# Altium schematic sheet 06_LMS7002M_RF.SchDoc(06_LMS7002M_RF)
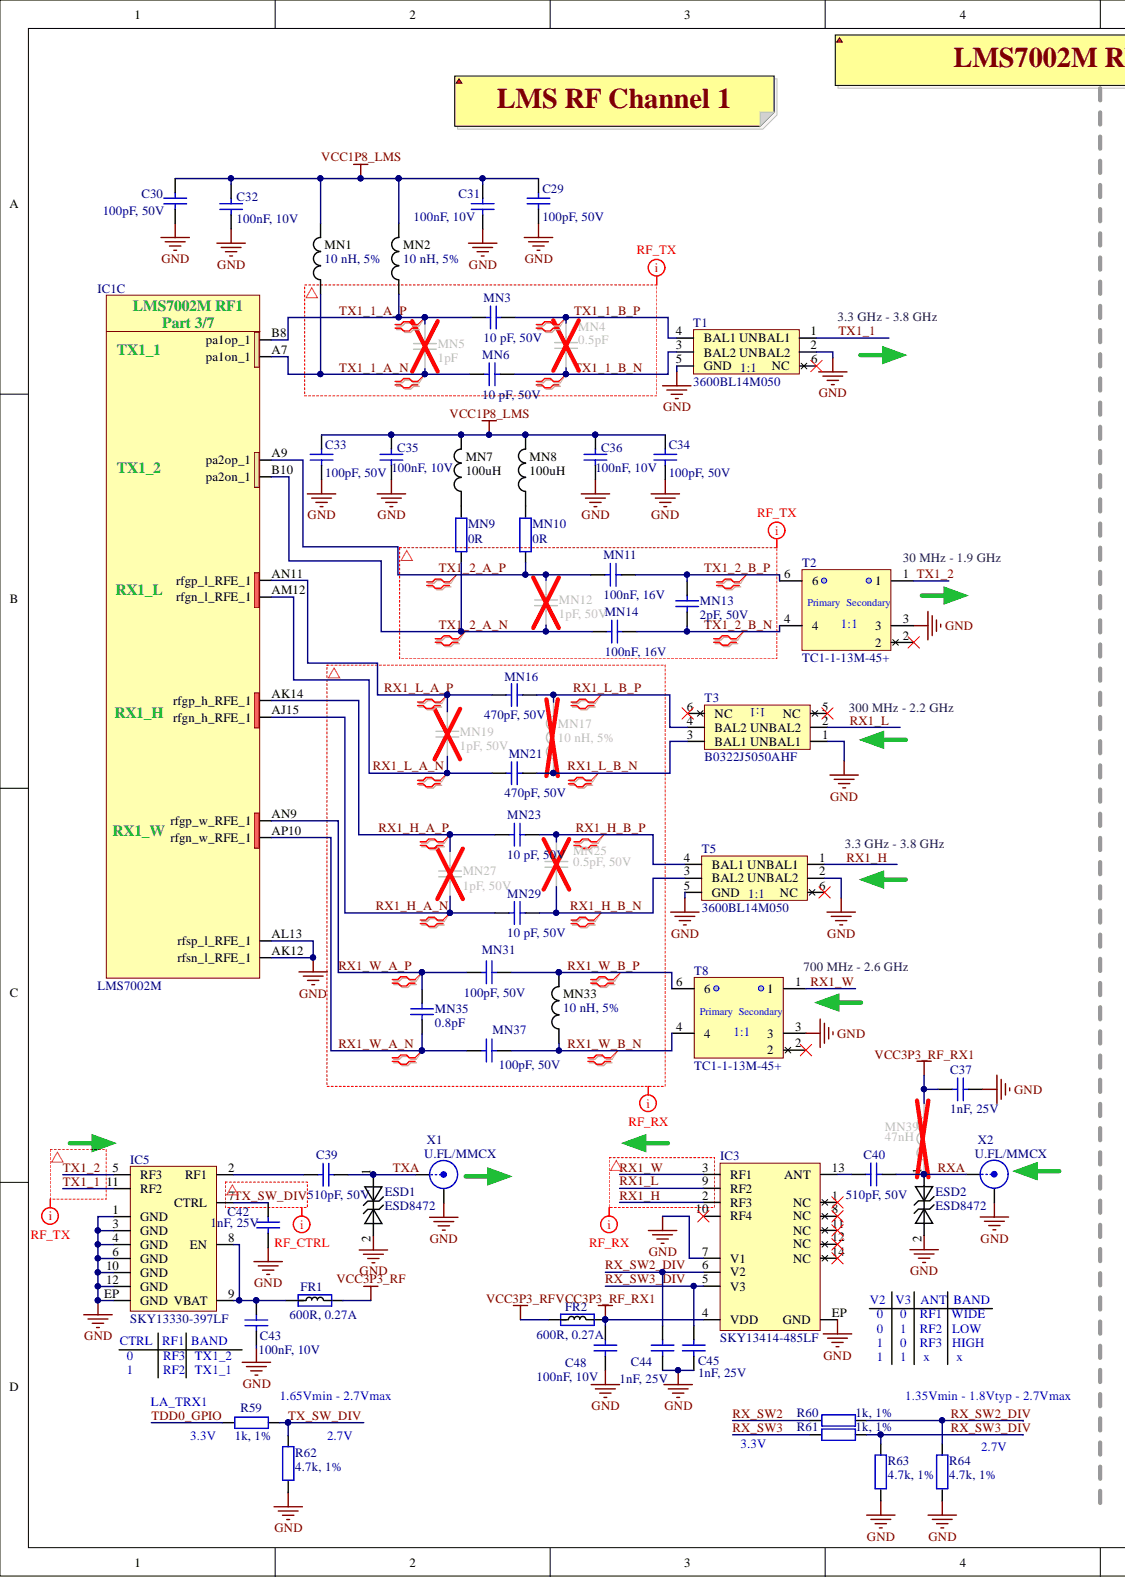
[diagram p0: 06_LMS7002M_RF.SchDoc, left]
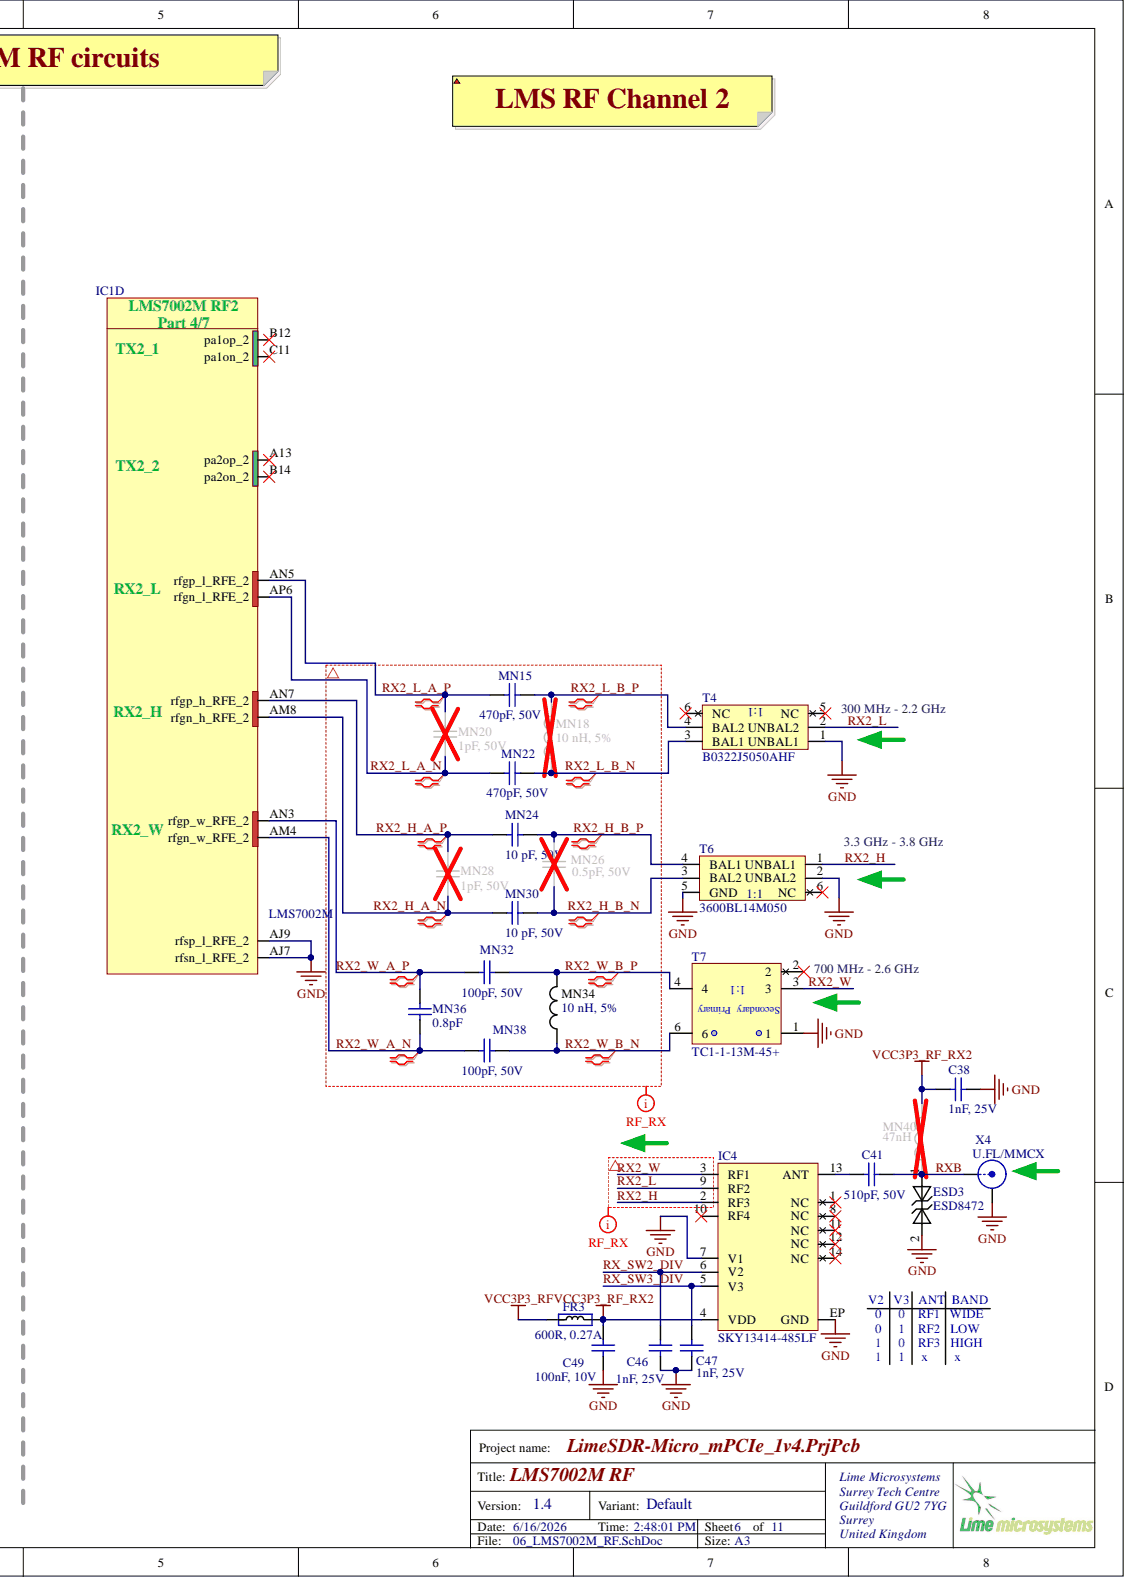
[diagram p1: 06_LMS7002M_RF.SchDoc, right]

## Parts
C29  100pF, 50V  Voltage Rating (DC): 50V  [CAP0201]
C30  100pF, 50V  Voltage Rating (DC): 50V  [CAP0201]
C31  100nF, 10V  Voltage Rating (DC): 10V  [CAP0201]
C32  100nF, 10V  [CAP0201]
C33  100pF, 50V  [CAP0201]
C34  100pF, 50V  Voltage Rating (DC): 50V  [CAP0201]
C35  100nF, 10V  [CAP0201]
C36  100nF, 10V  [CAP0201]
C37  1nF, 25V  Voltage - Rated: 25V  [CAP0201]
C38  1nF, 25V  Voltage - Rated: 25V  [CAP0201]
C39  510pF, 50V  Voltage - Rated: 50V  [CAP0402]
C40  510pF, 50V  Voltage - Rated: 50V  [CAP0402]
C41  510pF, 50V  Voltage - Rated: 50V  [CAP0402]
C42  1nF, 25V  Voltage - Rated: 25V  [CAP0201]
C43  100nF, 10V  Voltage Rating (DC): 10V  [CAP0201]
C44  1nF, 25V  Voltage - Rated: 25V  [CAP0201]
C45  1nF, 25V  Voltage - Rated: 25V  [CAP0201]
C46  1nF, 25V  Voltage - Rated: 25V  [CAP0201]
C47  1nF, 25V  Voltage - Rated: 25V  [CAP0201]
C48  100nF, 10V  Voltage Rating (DC): 10V  [CAP0201]
C49  100nF, 10V  Voltage Rating (DC): 10V  [CAP0201]
ESD1  ESD8472  [ESD8472_X3DFN2]
ESD2  ESD8472  [ESD8472_X3DFN2]
ESD3  ESD8472  [ESD8472_X3DFN2]
FR1  600R, 0.27A  [RES0201]
FR2  600R, 0.27A  [RES0201]
FR3  600R, 0.27A  [RES0201]
IC1  LMS7002M  [LMS7002M_v5_LESS_SILK]  (parts C,D)
IC3  SKY13414-485LF  [SKY13414-485LF_RF_SW_QFN14]
IC4  SKY13414-485LF  [SKY13414-485LF_RF_SW_QFN14]
IC5  SKY13330-397LF  [SKY13330-397LF_RF_SW_QFN12]
MN1  10 nH, 5%  [RES0402]
MN2  10 nH, 5%  Tolerance: 5%  [RES0402]
MN3  10 pF, 50V  Voltage Rating (DC): 50V  [CAP0402]
MN4  0.5pF  [CAP0402]
MN5  1pF  [CAP0402]
MN6  10 pF, 50V  Voltage Rating (DC): 50V  [CAP0402]
MN7  100uH  [RES0805]
MN8  100uH  [RES0805]
MN9  0R  [RES0402]
MN10  0R  [RES0402]
MN11  100nF, 16V  [CAP0402]
MN12  1pF, 50V  Voltage - Rated: 50V  [CAP0402]
MN13  2pF, 50V  [CAP0402]
MN14  100nF, 16V  [CAP0402]
MN15  470pF, 50V  Voltage - Rated: 50V  [CAP0402]
MN16  470pF, 50V  Voltage - Rated: 50V  [CAP0402]
MN17  10 nH, 5%  [RES0402]
MN18  10 nH, 5%  [RES0402]
MN19  1pF, 50V  Voltage - Rated: 50V  [CAP0402]
MN20  1pF, 50V  Voltage - Rated: 50V  [CAP0402]
MN21  470pF, 50V  Voltage - Rated: 50V  [CAP0402]
MN22  470pF, 50V  Voltage - Rated: 50V  [CAP0402]
MN23  10 pF, 50V  [CAP0402]
MN24  10 pF, 50V  Voltage Rating (DC): 50V  [CAP0402]
MN25  0.5pF, 50V  Voltage - Rated: 50V  [CAP0402]
MN26  0.5pF, 50V  Voltage - Rated: 50V  [CAP0402]
MN27  1pF, 50V  [CAP0402]
MN28  1pF, 50V  [CAP0402]
MN29  10 pF, 50V  Voltage Rating (DC): 50V  [CAP0402]
MN30  10 pF, 50V  Voltage Rating (DC): 50V  [CAP0402]
MN31  100pF, 50V  Voltage - Rated: 50V  [CAP0402]
MN32  100pF, 50V  Voltage - Rated: 50V  [CAP0402]
MN33  10 nH, 5%  [RES0402]
MN34  10 nH, 5%  [RES0402]
MN35  0.8pF  [CAP0402]
MN36  0.8pF  [CAP0402]
MN37  100pF, 50V  [CAP0402]
MN38  100pF, 50V  Voltage - Rated: 50V  [CAP0402]
MN39  47nH  [RES0402]
MN40  47nH  [RES0402]
R59  1k, 1%  Tolerance: 1%  [RES0201]
R60  1k, 1%  Tolerance: 1%  [RES0201]
R61  1k, 1%  Tolerance: 1%  [RES0201]
R62  4.7k, 1%  Tolerance: 1%  [RES0201]
R63  4.7k, 1%  Tolerance: 1%  [RES0201]
R64  4.7k, 1%  Tolerance: 1%  [RES0201]
T1  3600BL14M050  Turns Ratio: 1:1  [3600BL14M050_balun_0603]
T2  TC1-1-13M-45+  [TC1-1-13MA+_JA4220-AL_]
T3  B0322J5050AHF  Turns Ratio: 1:1  [Anaren_0805_balun_B0322J5050AHF]
T4  B0322J5050AHF  Turns Ratio: 1:1  [Anaren_0805_balun_B0322J5050AHF]
T5  3600BL14M050  Turns Ratio: 1:1  [3600BL14M050_balun_0603]
T6  3600BL14M050  Turns Ratio: 1:1  [3600BL14M050_balun_0603]
T7  TC1-1-13M-45+  [TC1-1-13MA+_JA4220-AL_]
T8  TC1-1-13M-45+  [TC1-1-13MA+_JA4220-AL_]
X1  U.FL/MMCX  [MMCX_UFL_conn_u_v2]
X2  U.FL/MMCX  [MMCX_UFL_conn_u_v2]
X4  U.FL/MMCX  [MMCX_UFL_conn_u_v2]

## Nets
GND: C29.1 C30.1 C31.2 C32.2 C33.1 C34.1 C35.2 C36.2 C37.2 C38.2 C42.2 C43.2 C44.2 C45.2 C46.2 C47.2 C48.2 C49.2 ESD1.2 ESD2.2 ESD3.2 IC1.AJ7(rfsn_l_RFE_2) IC1.AJ9(rfsp_l_RFE_2) IC1.AK12(rfsn_l_RFE_1) IC1.AL13(rfsp_l_RFE_1) IC3.7(V1) IC3.EP(GND) IC4.7(V1) IC4.EP(GND) IC5.1(GND) IC5.3(GND) IC5.4(GND) IC5.6(GND) IC5.10(GND) IC5.12(GND) IC5.EP(GND) R62.2 R63.2 R64.2 T1.2(UNBAL2) T1.5(GND) T2.3 T3.1(UNBAL1) T4.1(UNBAL1) T5.2(UNBAL2) T5.5(GND) T6.2(UNBAL2) T6.5(GND) T7.1 T8.3 X1.2 X2.2 X4.2
VCC1P8_LMS: C29.2 C30.2 C31.1 C32.1 C33.2 C34.2 C35.1 C36.1 MN1.2 MN2.2 MN7.2 MN8.2
VCC3P3_RF: FR1.1 FR2.2 FR3.2
VCC3P3_RF_RX1: C37.1 C48.1 FR2.1 IC3.4(VDD) MN39.2
VCC3P3_RF_RX2: C38.1 C49.1 FR3.1 IC4.4(VDD) MN40.2
RX1_H: IC3.2(RF3) T5.1(UNBAL1)
RX1_H_A_N: IC1.AJ15(rfgn_h_RFE_1) MN27.1 MN29.2
RX1_H_A_P: IC1.AK14(rfgp_h_RFE_1) MN23.2 MN27.2
RX1_H_B_N: MN25.1 MN29.1 T5.3(BAL2)
RX1_H_B_P: MN23.1 MN25.2 T5.4(BAL1)
RX1_L: IC3.9(RF2) T3.2(UNBAL2)
RX1_L_A_N: IC1.AM12(rfgn_l_RFE_1) MN19.1 MN21.2
RX1_L_A_P: IC1.AN11(rfgp_l_RFE_1) MN16.2 MN19.2
RX1_L_B_N: MN17.1 MN21.1 T3.3(BAL1)
RX1_L_B_P: MN16.1 MN17.2 T3.4(BAL2)
RX1_W: IC3.3(RF1) T8.1
RX1_W_A_N: IC1.AP10(rfgn_w_RFE_1) MN35.1 MN37.2
RX1_W_A_P: IC1.AN9(rfgp_w_RFE_1) MN31.2 MN35.2
RX1_W_B_N: MN33.1 MN37.1 T8.4
RX1_W_B_P: MN31.1 MN33.2 T8.6
RX2_H: IC4.2(RF3) T6.1(UNBAL1)
RX2_H_A_N: IC1.AM8(rfgn_h_RFE_2) MN28.1 MN30.2
RX2_H_A_P: IC1.AN7(rfgp_h_RFE_2) MN24.2 MN28.2
RX2_H_B_N: MN26.1 MN30.1 T6.3(BAL2)
RX2_H_B_P: MN24.1 MN26.2 T6.4(BAL1)
RX2_L: IC4.9(RF2) T4.2(UNBAL2)
RX2_L_A_N: IC1.AP6(rfgn_l_RFE_2) MN20.1 MN22.2
RX2_L_A_P: IC1.AN5(rfgp_l_RFE_2) MN15.2 MN20.2
RX2_L_B_N: MN18.1 MN22.1 T4.3(BAL1)
RX2_L_B_P: MN15.1 MN18.2 T4.4(BAL2)
RX2_W: IC4.3(RF1) T7.3
RX2_W_A_N: IC1.AM4(rfgn_w_RFE_2) MN36.1 MN38.2
RX2_W_A_P: IC1.AN3(rfgp_w_RFE_2) MN32.2 MN36.2
RX2_W_B_N: MN34.1 MN38.1 T7.6
RX2_W_B_P: MN32.1 MN34.2 T7.4
RX_SW2: R60.2
RX_SW2_DIV: C44.1 C46.1 IC3.6(V2) IC4.6(V2) R60.1 R64.1
RX_SW3: R61.2
RX_SW3_DIV: C45.1 C47.1 IC3.5(V3) IC4.5(V3) R61.1 R63.1
RXA: C40.1 ESD2.1 MN39.1 X2.1
RXB: C41.1 ESD3.1 MN40.1 X4.1
TDD0_GPIO: R59.2
TX1_1: IC5.11(RF2) T1.1(UNBAL1)
TX1_1_A_N: IC1.A7(pa1on_1) MN1.1 MN5.1 MN6.2
TX1_1_A_P: IC1.B8(pa1op_1) MN2.1 MN3.1 MN5.2
TX1_1_B_N: MN4.2 MN6.1 T1.3(BAL2)
TX1_1_B_P: MN3.2 MN4.1 T1.4(BAL1)
TX1_2: IC5.5(RF3) T2.1
TX1_2_A_N: IC1.B10(pa2on_1) MN9.1 MN12.2 MN14.1
TX1_2_A_P: IC1.A9(pa2op_1) MN10.1 MN11.2 MN12.1
TX1_2_B_N: MN13.1 MN14.2 T2.4
TX1_2_B_P: MN11.1 MN13.2 T2.6
TX_SW_DIV: C42.1 IC5.7(CTRL) R59.1 R62.1
TXA: C39.1 ESD1.1 X1.1
NetC39_2: C39.2 IC5.2(RF1)
NetC40_2: C40.2 IC3.13(ANT)
NetC41_2: C41.2 IC4.13(ANT)
NetC43_1: C43.1 FR1.2 IC5.8(EN) IC5.9(VBAT)
NetMN7_1: MN7.1 MN9.2
NetMN8_1: MN8.1 MN10.2
N00140:
N00141:
N00142:
N00143:
N00144:
N00145:
N00146:
N00147:

Not connected: IC1 A13(pa2op_2) B12(pa1op_2) B14(pa2on_2) C11(pa1on_2); IC3 1(NC) 8(NC) 10(RF4) 11(NC) 12(NC) 14(NC); IC4 1(NC) 8(NC) 10(RF4) 11(NC) 12(NC) 14(NC); T1 6(NC); T2 2; T3 5(NC) 6(NC); T4 5(NC) 6(NC); T5 6(NC); T6 6(NC); T7 2; T8 2

## Library data of the parts
C29 C30 C33 C34 = Cap_0402: Part Description: 100 pF ±5% 50V Ceramic Capacitor C0G, NP0 0201 (0603 Metric); Manufacturer Part Number 1: C0603C0G1H101J030BA; Manufacturer: TDK; Tolerance: 5%; Insulation Resistance: 10GR; Dielectric Material: Ceramic; Dielectric: C0G; Width: 0.012inch; Weight: 6E-06oz; Voltage Rating: 50V; Voltage: 50V; Value: 100pF, 50V; Thickness: 0.013inch; Termination: SMD/SMT; Supplier Part Number 1: 445-1786-1-ND; Supplier 1: Digi-Key; Stock 1: 367528; Series: C; RoHS Compliant: Yes; RoHS: Yes; Reach SVHC Compliant: Yes; Pricing 1: 1=0,10000, 10=0,06000, 50=0,03280, 100=0,02670, 500=0,01908, 1000=0,01500, 2500=0,01364, 5000=0,01254 (USD); Packaging: Tape and Reel; Package Quantity: 1; Number of Pins: 2; Mount: Surface Mount; Min Operating Temperature: -55°C; Max Operating Temperature: 125°C; Length: 0.6mm; Lead Free: Lead Free; Height: 0.3mm; Depth: 0.3mm; Construction: Flat; ComponentLink3URL: https://datasheet.ciiva.com/6250/tdkmlcccaprange-6250351.pdf?src-supplier=Digi-Key; ComponentLink3Description: https://datasheet.ciiva.com/6250/tdkmlcccaprange-6250351.pdf?src-supplier=Digi-Key; ComponentLink2URL: https://datasheet.ciiva.com/16205/mlcc-commercial-general-en-16205590.pdf?src-supplier=Future+Electronics; ComponentLink2Description: https://datasheet.ciiva.com/16205/mlcc-commercial-general-en-16205590.pdf?src-supplier=Future+Electronics; ComponentLink1URL: https://datasheet.ciiva.com/24217/c0603c0g1h101j030ba-264106-24217425.pdf?src-supplier=Mouser; ComponentLink1Description: https://datasheet.ciiva.com/24217/c0603c0g1h101j030ba-264106-24217425.pdf?src-supplier=Mouser; Component Kind: Standard; Case Code (Metric): 0603; Case Code (Imperial): 0201; Case/Package: 0201; Capacitance: 100pF; Library Name: LimeMicroAltiumLib_schLib.SchLib
  C33: Voltage Rating (DC): 50V
C31 C32 C35 C36 C43 C48 C49 = Cap_0402: Part Description: 0.1 µF ±20% 10V Ceramic Capacitor X5R 0201 (0603 Metric); Manufacturer Part Number 1: CL03A104MP3NNNC; Manufacturer: Samsung; Tolerance: 20%; Width: 0.012inch; Voltage Rating: 10V; Value: 100nF, 10V; Thickness: 0.013inch; Supplier Part Number 1: 1276-1321-1-ND; Supplier 1: Digi-Key; Stock 1: 3817176; Series: CL; RoHS Compliant: Yes; RoHS: Yes; Pricing 1: 1=0,10000, 10=0,03000, 50=0,01640, 100=0,01330, 500=0,00950, 1000=0,00747, 2500=0,00679, 5000=0,00625 (USD); Packaging: Tape and Reel; Package Quantity: 1; Mount: Surface Mount; Min Operating Temperature: -55°C; Max Operating Temperature: 85°C; Length: 0.024inch; ComponentLink5URL: https://datasheet.ciiva.com/25788/cl03a104mp3nnnc-25788272.pdf?src-supplier=Digi-Key; ComponentLink5Description: https://datasheet.ciiva.com/25788/cl03a104mp3nnnc-25788272.pdf?src-supplier=Digi-Key; Component Kind: Standard; Case Code (Metric): 0603; Case Code (Imperial): 0201; Case/Package: 0201; Capacitance: 100nF; Library Name: LimeMicroAltiumLib_schLib.SchLib
  C32 C35 C36: Voltage Rating (DC): 10V
C37 C38 C42 C44-C47 = Cap_0402: Part Description: 1000pF ±10% 25V X7R Ceramic Capacitor -55°C ~ 125°C Surface Mount, MLCC 0201 (0603 Metric) 0.024" L x 0.012" W (0.60mm x 0.30mm); Manufacturer Part Number 1: C0603X7R1E102K030BA; Manufacturer: TDK Corporation; Tolerance: ±10%; Temperature Coefficient: X7R; Capacitance: 1000pF; Value: 1nF, 25V; Thickness (Max): 0.013" (0.33mm); Supplier Part Number 1: 445-7275-1-ND; Supplier 1: Digi-Key; Size / Dimension: 0.024" L x 0.012" W (0.60mm x 0.30mm); RoHS: RoHS Compliant; Ratings: -; Packaging: Cut Tape (CT); Package / Case: 0201 (0603 Metric); Operating Temperature: -55°C ~ 125°C; Mounting Type: Surface Mount, MLCC; Lead Style: -; Lead Spacing: -; Height - Seated (Max): -; Features: -; Component Kind: Standard; Category: Capacitors; Applications: General Purpose; Library Name: LimeMicroAltiumLib_schLib.SchLib
C39-C41 = Cap_0402: Part Description: 510pF ±5% 50V C0G, NP0 Ceramic Capacitor -55°C ~ 125°C Surface Mount, MLCC 0402 (1005 Metric) 0.039" L x 0.020" W (1.00mm x 0.50mm); Manufacturer Part Number 1: GRM1555C1H511JA01D; Manufacturer: Murata Electronics North America; Tolerance: ±5%; Temperature Coefficient: C0G, NP0; Value: 510pF, 50V; Thickness (Max): 0.022" (0.55mm); Supplier Part Number 1: 490-3237-1-ND; Supplier 1: Digi-Key; Size / Dimension: 0.039" L x 0.020" W (1.00mm x 0.50mm); RoHS: RoHS Compliant; Ratings: -; Packaging: Cut Tape (CT); Package / Case: 0402 (1005 Metric); Operating Temperature: -55°C ~ 125°C; Mounting Type: Surface Mount, MLCC; Lead Style: -; Lead Spacing: -; Height - Seated (Max): -; Features: -; Component Kind: Standard; Category: Capacitors; Capacitance: 510pF; Applications: General Purpose; Library Name: LimeMicroAltiumLib_schLib.SchLib
ESD1-ESD3 = ESD8472: Part Description: TVS DIODE 5.3VWM 14VC X3DFN2; Manufacturer Part Number 1: ESD8472MUT5G; Manufacturer: ON Semiconductor; Voltage - Reverse Standoff (Typ): 5.3V (Max); Voltage - Clamping (Max) @ Ipp: 14V; Voltage - Breakdown (Min): 7V; Power Line Protection: No; Current - Peak Pulse (10/1000µs): 3A (8/20µs); Capacitance @ Frequency: 0.2pF @ 1MHz; Type: Zener; Supplier Part Number 1: ESD8472MUT5GOSCT-ND; Supplier Device Package: 2-X3DFN (0.62x0.32); Supplier 1: Digi-Key; Stock 1: 2295; RoHS: RoHS Compliant; Pricing 1: 1=0.39, 10=0.315, 100=0.2142, 500=0.16068, 1000=0.12051 (USD); Power - Peak Pulse: -; Part Status: Active; Packaging: Cut Tape (CT); Package / Case: 2-XFDFN; Operating Temperature: -55°C ~ 150°C (TJ); Mounting Type: Surface Mount; ComponentLink1URL: http://www.onsemi.com/pub/Collateral/ESD8472-D.PDF; ComponentLink1Description: http://www.onsemi.com/pub/Collateral/ESD8472-D.PDF; Component Kind: Standard; Category: Circuit Protection; Applications: Automotive, RF Antenna; Library Name: LimeMicroAltiumLib_schLib.SchLib
FR1-FR3 = Ferrite: Part Description: FERRITE BEAD 600 OHM 0201 1LN; Manufacturer Part Number 1: BK0603TS601-T; Manufacturer: Taiyo Yuden; Value: 600R@100MHz; Impedance @ Frequency: 600 Ohm @ 100MHz; Filter Type: Differential Mode - Single; DC Resistance (DCR): 750 mOhm Max; Current Rating: 270mA; Supplier Part Number 1: 587-3277-1-ND; Supplier 1: Digi-Key; Size / Dimension: 0.024" L x 0.012" W (0.60mm x 0.30mm); RoHS: RoHS Compliant; Packaging: Cut Tape (CT); Package / Case: 0201 (0603 Metric); Mounting Type: Surface Mount; Height (Max): 0.013" (0.33mm); Component Kind: Standard; Category: Filters; Library Name: LimeMicroAltiumLib_schLib.SchLib
IC1 = LMS7002Mr4: Schematic symbol revision 4. Default footprint - 0.31mm pad; Part Description: IC FPRF Transceiver LMS7002M; 261 pin aQFN; Manufacturer: Lime Microsystems; Supplier Part Number 1: LMS7002M; Supplier 1: Lime Microsystems; Manufacturer Part Number 1: LMS7002M; Library Name: LimeMicroAltiumLib_schLib_r04.SchLib; Component Kind: Standard
IC3 IC4 = SKY13414-485LF_RF_SW: Part Description: RF Switch IC General Purpose SP4T 3 GHz 50Ohm 14-QFN (2x2); Manufacturer: Skyworks Solutions; Throw Configuration: SP4T; P1dB: 37dBm; Impedance: 50R; IIP3: 70dBm; Supplier Part Number 1: 863-1582-1-ND; Supplier 1: Digi-Key; Stock 1: 43522; RoHS Compliant: Yes; RoHS: Yes; Pricing 1: 1=1,35000, 10=1,20700, 25=1,14520, 100=0,94070, 250=0,87936, 500=0,77710, 1000=0,61350 (USD); Packaging: Tape and Reel; Package Quantity: 3000; Min Operating Temperature: -40°C; Max Operating Temperature: 85°C; Manufacturer Part Number 1: SKY13414-485LF; ComponentLink1URL: https://datasheet.ciiva.com/15309/sky13414-485lf-201689g-231640-15309794.pdf?src-supplier=Mouser; ComponentLink1Description: https://datasheet.ciiva.com/15309/sky13414-485lf-201689g-231640-15309794.pdf?src-supplier=Mouser; Component Kind: Standard; Case/Package: QFN; Library Name: LimeMicroAltiumLib_schLib_r04.SchLib
IC5 = SKY13330-397LF_RF_SW: Part Description: RF Switch IC W-CDMA SPDT 6 GHz 50Ohm 12-QFN (2x2); Manufacturer: Skyworks Solutions; Turn-On Delay Time: 1.6us; Throw Configuration: SPDT; P1dB: 39dBm; Max Frequency: 6GHz; Insertion Loss: 0.65dB; Impedance: 50R; Frequency: 100MHz; Weight: 0.000776oz; Turn-Off Delay Time: 1.6us; Supplier Part Number 1: 863-1643-1-ND; Supplier 1: Digi-Key; Stock 1: 596826; RoHS: Yes; Radiation Hardening: No; Pricing 1: 1=0,99000, 10=0,88800, 25=0,84280, 100=0,69230, 250=0,64716, 500=0,57190, 1000=0,45150 (USD); Packaging: Tape and Reel; Package Quantity: 3000; Number of Pins: 12; Mount: Surface Mount; Min Operating Temperature: -40°C; Max Operating Temperature: 85°C; Manufacturer Part Number 1: SKY13330-397LF; Contact Plating: Tin,Matte; ComponentLink1URL: https://datasheet.ciiva.com/15100/getdatasheetpartid-656010-15100460.pdf?src-supplier=Verical; ComponentLink1Description: https://datasheet.ciiva.com/15100/getdatasheetpartid-656010-15100460.pdf?src-supplier=Verical; Component Kind: Standard; Case/Package: QFN; Library Name: LimeMicroAltiumLib_schLib_r04.SchLib
MN1 MN2 MN17 MN18 MN33 MN34 = Inductor_0603: Part Description: RF Inductors - SMD 10 NH 5%; Manufacturer Part Number 1: LQW15AN10NJ00D; Manufacturer: Murata; Test Frequency: 100MHz; Shielding: Unshielded; Self Resonant Frequency: 5.5GHz; Rated Current-Max: 500mA; Q Factor: 25; Inductance: 10nH; DC Resistance (DCR): 170mR; Core Material: Air,Ferrite; Width: 0.02inch; Weight: 2.8E-05oz; Termination: SMD/SMT; Supplier Part Number 1: 490-1146-6-ND; Supplier 1: Digi-Key; Stock 1: 158333; Series: LQW15A_00; RoHS Compliant: Yes; RoHS: Yes; Resistor Type: Wirewound; Radiation Hardening: No; Pricing 1: 1=0,16000, 10=0,14200, 25=0,12600, 50=0,10720, 100=0,09450, 250=0,08664, 500=0,07876, 1000=0,06615, 2500=0,05670 (USD); Packaging: Tape and Reel; Package Quantity: 10000; Mount: Surface Mount; Min Operating Temperature: -55°C; Military Standard: Not; Max Operating Temperature: 125°C; Max DC Current: 500mA; Length: 1mm; Lead Free: Lead Free; Height: 0.5mm; Depth: 0.7mm; DC Current: 500mA; Current Rating: 500mA; ComponentLink7URL: https://datasheet.ciiva.com/16210/l0075s0080lqw15a-00-16210412.pdf?src-supplier=Future+Electronics; ComponentLink7Description: https://datasheet.ciiva.com/16210/l0075s0080lqw15a-00-16210412.pdf?src-supplier=Future+Electronics; ComponentLink6URL: https://datasheet.ciiva.com/18089/getdatasheetpartid-110925-18089287.pdf?src-supplier=Verical; ComponentLink6Description: https://datasheet.ciiva.com/18089/getdatasheetpartid-110925-18089287.pdf?src-supplier=Verical; ComponentLink5URL: https://datasheet.ciiva.com/8508/l0075s0080lqw15a-00-8508743.pdf?src-supplier=Avnet; ComponentLink5Description: https://datasheet.ciiva.com/8508/l0075s0080lqw15a-00-8508743.pdf?src-supplier=Avnet; ComponentLink4URL: https://datasheet.ciiva.com/1042/l0075s0080lqw15a-00-1042164.pdf?src-supplier=Digi-Key; ComponentLink4Description: https://datasheet.ciiva.com/1042/l0075s0080lqw15a-00-1042164.pdf?src-supplier=Digi-Key; ComponentLink3URL: https://datasheet.ciiva.com/1042/l0075s0080lqw15a-00-1042163.pdf?src-supplier=Digi-Key; ComponentLink3Description: https://datasheet.ciiva.com/1042/l0075s0080lqw15a-00-1042163.pdf?src-supplier=Digi-Key; ComponentLink2URL: https://datasheet.ciiva.com/1042/l0075s0080lqw15a-00-1042162.pdf?src-supplier=Digi-Key; ComponentLink2Description: https://datasheet.ciiva.com/1042/l0075s0080lqw15a-00-1042162.pdf?src-supplier=Digi-Key; ComponentLink1URL: https://datasheet.ciiva.com/11799/0900766b8090d800-11799037.pdf?src-supplier=RSComponents; ComponentLink1Description: https://datasheet.ciiva.com/11799/0900766b8090d800-11799037.pdf?src-supplier=RSComponents; Component Kind: Standard; Case Code (Metric): 1005; Case Code (Imperial): 0402; Case/Package: 0402; Library Name: LimeMicroAltiumLib_schLib.SchLib
  MN1 MN17 MN18 MN33 MN34: Tolerance: 5%
MN3 MN6 MN23 MN24 MN29 MN30 = Cap_0402: Part Description: 10 pF ±0.5pF 50V Ceramic Capacitor C0G, NP0 0402 (1005 Metric); Manufacturer Part Number 1: 0402N100D500CT; Manufacturer: Walsin Technologies; Dielectric: C0G; Capacitance: 10pF; Voltage Rating: 50V; Supplier Part Number 1: 1292-0402N100D500CT-ND; Supplier 1: Digi-Key; Stock 1: 66767; RoHS Compliant: Yes; RoHS: Yes; Pricing 1: 1=0,10000, 10=0,01200, 50=0,00660, 100=0,00540, 500=0,00388, 1000=0,00306, 2500=0,00278, 5000=0,00256 (USD); Packaging: Tape and Reel; Min Operating Temperature: -55°C; Max Operating Temperature: 125°C; ComponentLink2URL: http://www.farnell.com/datasheets/1958513.pdf?src-supplier=Element14; ComponentLink2Description: http://www.farnell.com/datasheets/1958513.pdf?src-supplier=Element14; ComponentLink1URL: http://www.farnell.com/datasheets/2340522.pdf?src-supplier=Farnell; ComponentLink1Description: http://www.farnell.com/datasheets/2340522.pdf?src-supplier=Farnell; Component Kind: Standard; Case Code (Metric): 1005; Case Code (Imperial): 0402; Case/Package: 0402; Applications: General Purpose; Library Name: LimeMicroAltiumLib_schLib.SchLib
  MN23: Voltage Rating (DC): 50V
MN4 = Cap_0402: Part Description: 0.50pF ±0.25pF 50V C0G, NP0 Ceramic Capacitor -55°C ~ 125°C Surface Mount, MLCC 0402 (1005 Metric) 0.039" L x 0.020" W (1.00mm x 0.50mm); Manufacturer Part Number 1: CC0402CRNPO9BNR50; Manufacturer: Yageo; Voltage - Rated: 50V; Tolerance: ±0.25pF; Temperature Coefficient: C0G, NP0; Capacitance: 0.50pF; Value: 0.5pF; Thickness (Max): 0.022" (0.55mm); Supplier Part Number 1: 311-1001-1-ND; Supplier 1: Digi-Key; Size / Dimension: 0.039" L x 0.020" W (1.00mm x 0.50mm); RoHS: RoHS Compliant; Ratings: -; Packaging: Cut Tape (CT); Package / Case: 0402 (1005 Metric); Operating Temperature: -55°C ~ 125°C; Mounting Type: Surface Mount, MLCC; Lead Style: -; Lead Spacing: -; Height - Seated (Max): -; Features: -; Component Kind: Standard; Category: Capacitors; Applications: General Purpose; Library Name: LimeMicroAltiumLib_schLib.SchLib
MN5 = Cap_0402: Part Description: 1pF ±0.1pF 50V C0G, NP0 Ceramic Capacitor -55°C ~ 125°C Surface Mount, MLCC 0402 (1005 Metric) 0.039" L x 0.020" W (1.00mm x 0.50mm); Manufacturer Part Number 1: C1005C0G1H010B050BA; Manufacturer: TDK Corporation; Voltage - Rated: 50V; Tolerance: ±0.1pF; Temperature Coefficient: C0G, NP0; Value: 1pF; Thickness (Max): 0.022" (0.55mm); Supplier Part Number 1: 445-4854-6-ND; Supplier 1: Digi-Key; Size / Dimension: 0.039" L x 0.020" W (1.00mm x 0.50mm); RoHS: RoHS Compliant; Ratings: -; Packaging: Digi-Reel®; Package / Case: 0402 (1005 Metric); Operating Temperature: -55°C ~ 125°C; Mounting Type: Surface Mount, MLCC; Lead Style: -; Lead Spacing: -; Height - Seated (Max): -; Features: -; Component Kind: Standard; Category: Capacitors; Capacitance: 1pF; Applications: General Purpose; Library Name: LimeMicroAltiumLib_schLib.SchLib
MN7 MN8 = Inductor_0603: Part Description: FIXED IND 100UH 2MA 3.1 OHM SMD; Manufacturer Part Number 1: MLF2012C101KT000; Manufacturer: TDK Corporation; Tolerance: ±10%; Shielding: Shielded; Q @ Freq: 25 @ 1MHz; Material - Core: Ferrite; Inductance: 100µH; Frequency - Test: 1MHz; Frequency - Self Resonant: 7MHz; DC Resistance (DCR): 3.1 Ohm Max; Current Rating: 2mA; Value: 100uH; Type: Multilayer; Supplier Part Number 1: 445-1071-1-ND; Supplier Device Package: 0805 (2012 Metric); Supplier 1: Digi-Key; Size / Dimension: 0.079" L x 0.049" W (2.00mm x 1.25mm); RoHS: RoHS Compliant; Packaging: Cut Tape (CT); Package / Case: 0805 (2012 Metric); Operating Temperature: -40°C ~ 85°C; Mounting Type: Surface Mount; Height - Seated (Max): 0.057" (1.45mm); Current - Saturation: -; Component Kind: Standard; Category: Inductors, Coils, Chokes; Library Name: LimeMicroAltiumLib_schLib.SchLib
MN9 MN10 = Res_0402: Part Description: RES SMD 0.0OHM JUMPER 1/16W 0402; Manufacturer Part Number 1: RC0402JR-070RL; Manufacturer: Yageo; Tolerance: Jumper; Resistance (Ohms): 0.0; Power (Watts): 0.063W, 1/16W; Value: 0R; Temperature Coefficient: -; Supplier Part Number 1: 311-0.0JRTR-ND; Supplier Device Package: 0402; Supplier 1: Digi-Key; Size / Dimension: 0.039" L x 0.020" W (1.00mm x 0.50mm); RoHS: RoHS Compliant; Packaging: Tape & Reel (TR); Package / Case: 0402 (1005 Metric); Operating Temperature: -55°C ~ 155°C; Number of Terminations: 2; Height: 0.016" (0.40mm); Features: Moisture Resistant; Composition: Thick Film; Component Kind: Standard; Category: Resistors; Library Name: LimeFPGAboard_schLib.SchLib
MN11 MN14 = Cap_0402: Part Description: 0.10µF ±10% 16V X7R Ceramic Capacitor -55°C ~ 125°C Surface Mount, MLCC 0402 (1005 Metric) 0.039" L x 0.020" W (1.00mm x 0.50mm); Manufacturer Part Number 1: GRM155R71C104KA88D; Manufacturer: Murata Electronics; Tolerance: 10 %; Temperature Coefficient / Code: +/- 15 %; Dielectric: X7R; Case Width: 0.5 mm; Case Length: 1 mm; Capacitance: 0.1 uF; Voltage Rating DC: 16 V; Value: 100nF, 16V; Type: General Purpose MLCC; Termination Style: SMD/SMT; Supplier Part Number 1: 81-GRM155R71C104KA88; Supplier 1: Mouser; Standard Pack Qty: 10000; Series: GRM; RoHS - Mouser: Y; RoHS: RoHS Compliant; Reeling: True; Product Category: Low & Medium Capacitance SMD Ceramic Chip Capacitors; Product: General Type MLCCs; Packaging: Reel; Package / Case: 0402 (1005 metric); Operating Temperature Range: - 55 C to + 125 C; Minimum Order Quantity: 1; Minimum Operating Temperature: - 55 C; Maximum Operating Temperature: + 125 C; Manufacturer Part Number: GRM155R71C104KA88D; Component Kind: Standard; Category: Multilayer Ceramic Capacitors MLCC - SMD/SMT; Case Height: 0.5 mm; Case Code - mm: 1005; Case Code - in: 0402; Brand: Murata Electronics; Library Name: LimeMicroAltiumLib_schLib.SchLib
MN12 MN19 MN20 MN27 MN28 = Cap_0402: Part Description: 1pF ±0.1pF 50V C0G, NP0 Ceramic Capacitor -55°C ~ 125°C Surface Mount, MLCC 0402 (1005 Metric) 0.039" L x 0.020" W (1.00mm x 0.50mm); Manufacturer Part Number 1: C1005C0G1H010B050BA; Manufacturer: TDK Corporation; Tolerance: ±0.1pF; Temperature Coefficient: C0G, NP0; Value: 1pF, 50V; Thickness (Max): 0.022" (0.55mm); Supplier Part Number 1: 445-4854-6-ND; Supplier 1: Digi-Key; Size / Dimension: 0.039" L x 0.020" W (1.00mm x 0.50mm); RoHS: RoHS Compliant; Ratings: -; Packaging: Digi-Reel®; Package / Case: 0402 (1005 Metric); Operating Temperature: -55°C ~ 125°C; Mounting Type: Surface Mount, MLCC; Lead Style: -; Lead Spacing: -; Height - Seated (Max): -; Features: -; Component Kind: Standard; Category: Capacitors; Capacitance: 1pF; Applications: General Purpose; Library Name: LimeMicroAltiumLib_schLib.SchLib
  MN27 MN28: Voltage - Rated: 50V
MN13 = Cap_0402: Part Description: SMD Multilayer Ceramic Capacitor, U Series, 2 pF, ± 0.1pF, C0G / NP0, 50 V, 0402 [1005 Metric]; Manufacturer Part Number 1: 04025U2R0BAT2A; Manufacturer: AVX; Voltage Rating: 50; SVHC: No SVHC (17-Dec-2014); Product Range: U Series; Dielectric Characteristic: C0G / NP0; Ceramic Capacitor Case: 0402 [1005 Metric]; Capacitance Tolerance ±: ± 0.1; Capacitance: 2; Value: 2pF, 50V; Supplier Part Number 1: 2332536; Supplier 1: Farnell; RoHS: YES; Packaging: Cut Tape; Operating Temperature Min: -55; Operating Temperature Max: 125; MSL: MSL 1 - Unlimited; Component Kind: Standard; Capacitance Tolerance: ± 0.1; Library Name: LimeMicroAltiumLib_schLib.SchLib
MN15 MN16 MN21 MN22 = Cap_0402: Part Description: 470pF ±5% 50V Ceramic Capacitor C0G, NP0 0402 (1005 Metric); Manufacturer Part Number 1: C1005C0G1H471J050BA; Manufacturer: TDK Corporation; Tolerance: ±5%; Temperature Coefficient: C0G, NP0; Value: 470pF, 50V; Thickness (Max): 0.022" (0.55mm); Supplier Part Number 1: 445-2656-6-ND; Supplier 1: Digi-Key; Size / Dimension: 0.039" L x 0.020" W (1.00mm x 0.50mm); RoHS: RoHS Compliant; Ratings: -; Packaging: Digi-Reel®; Package / Case: 0402 (1005 Metric); Operating Temperature: -55°C ~ 125°C; Mounting Type: Surface Mount, MLCC; Manufacturer Part Number: C1005C0G1H471J050BA; Lead Style: -; Lead Spacing: -; Height - Seated (Max): -; Features: -; Component Kind: Standard; Category: Capacitors; Capacitance: 470pF; Applications: General Purpose; Library Name: LimeMicroAltiumLib_schLib.SchLib
MN25 MN26 = Cap_0402: Part Description: 0.50pF ±0.25pF 50V C0G, NP0 Ceramic Capacitor -55°C ~ 125°C Surface Mount, MLCC 0402 (1005 Metric) 0.039" L x 0.020" W (1.00mm x 0.50mm); Manufacturer Part Number 1: CC0402CRNPO9BNR50; Manufacturer: Yageo; Tolerance: ±0.25pF; Temperature Coefficient: C0G, NP0; Capacitance: 0.50pF; Value: 0.5pF, 50V; Thickness (Max): 0.022" (0.55mm); Supplier Part Number 1: 311-1001-1-ND; Supplier 1: Digi-Key; Size / Dimension: 0.039" L x 0.020" W (1.00mm x 0.50mm); RoHS: RoHS Compliant; Ratings: -; Packaging: Cut Tape (CT); Package / Case: 0402 (1005 Metric); Operating Temperature: -55°C ~ 125°C; Mounting Type: Surface Mount, MLCC; Lead Style: -; Lead Spacing: -; Height - Seated (Max): -; Features: -; Component Kind: Standard; Category: Capacitors; Applications: General Purpose; Library Name: LimeMicroAltiumLib_schLib.SchLib
MN31 MN32 MN37 MN38 = Cap_0402: Part Description: 100pF ±5% 50V C0G, NP0 Ceramic Capacitor -55°C ~ 125°C Surface Mount, MLCC 0402 (1005 Metric) 0.039" L x 0.020" W (1.00mm x 0.50mm); Manufacturer Part Number 1: CC0402JRNPO9BN101; Manufacturer: Yageo; Tolerance: ±5%; Temperature Coefficient: C0G, NP0; Value: 100pF, 50V; Thickness (Max): 0.022" (0.55mm); Supplier Part Number 1: 311-1024-1-ND; Supplier 1: Digi-Key; Size / Dimension: 0.039" L x 0.020" W (1.00mm x 0.50mm); RoHS: RoHS Compliant; Ratings: -; Packaging: Cut Tape (CT); Package / Case: 0402 (1005 Metric); Operating Temperature: -55°C ~ 125°C; Mounting Type: Surface Mount, MLCC; Lead Style: -; Lead Spacing: -; Height - Seated (Max): -; Features: -; Component Kind: Standard; Category: Capacitors; Capacitance: 100pF; Applications: General Purpose; Library Name: LimeMicroAltiumLib_schLib.SchLib
  MN37: Voltage - Rated: 50V
MN35 MN36 = Cap_0402: Part Description: 0.80pF ±0.1pF 50V C0G, NP0 Ceramic Capacitor -55°C ~ 125°C Surface Mount, MLCC 0402 (1005 Metric) 0.039" L x 0.020" W (1.00mm x 0.50mm); Manufacturer Part Number 1: GRM1555C1HR80BA01D; Manufacturer: Murata Electronics North America; Voltage - Rated: 50V; Tolerance: ±0.1pF; Temperature Coefficient: C0G, NP0; Capacitance: 0.80pF; Value: 0.8pF; Thickness (Max): 0.022" (0.55mm); Supplier Part Number 1: 490-6269-1-ND; Supplier 1: Digi-Key; Size / Dimension: 0.039" L x 0.020" W (1.00mm x 0.50mm); RoHS: RoHS Compliant; Ratings: -; Packaging: Cut Tape (CT); Package / Case: 0402 (1005 Metric); Operating Temperature: -55°C ~ 125°C; Mounting Type: Surface Mount, MLCC; Lead Style: -; Lead Spacing: -; Height - Seated (Max): -; Features: -; Component Kind: Standard; Category: Capacitors; Applications: General Purpose; Library Name: LimeMicroAltiumLib_schLib.SchLib
MN39 MN40 = Inductor_0603: Part Description: INDUCTOR, RF, 47NH, 5%, 2.4GHZ, SMD; Product Range:0402HP Series; Inductance:47nH; Inductance Tolerance:± 5%; High Frequency Inductor Case:0402 [1005 Metric]; Self Resonant Frequency Min:2.4GHz; DC Resistance Max:0.58ohm; DC Current Rating:420mA; Manufacturer Part Number 1: 0402HP-47NXJLU; Manufacturer: COILCRAFT; SVHC: No SVHC (15-Jun-2015); Self Resonant Frequency Min: 2.4; Product Range: 0402; Inductor Construction: Wirewound; Inductor Case Style: 0402 [1005 Metric]; Inductance Tolerance: ± 5%; Inductance: 47; DC Resistance Max: 0.58; DC Current Rating: 420; value: 47nH; Supplier Part Number 1: 2285856; Supplier 1: Farnell; RoHS: Y-EX; Packaging: Cut Tape; Manufacturer Part Number: 0402HP-47NXJLU; High Frequency Inductor Case: 0402 [1005 Metric]; Component Kind: Standard; Library Name: LimeMicroAltiumLib_schLib.SchLib
R59-R61 = Res_0402: Part Description: 1 kOhms ±1% 0.05W, 1/20W Chip Resistor 0201 (0603 Metric) Moisture Resistant Thick Film; Manufacturer Part Number 1: RC0201FR-071KL; Manufacturer: Yageo; Working Voltage: 25V; Temperature Coefficient: 200ppm/°C; Resistance: 1kR; Power Rating: 50mW; Width: 0.012inch; Voltage Rating: 25V; Value: 1k, 1%; Termination: SMD/SMT; Technology: THICK FILM; Supplier Part Number 1: 311-1KMDKR-ND; Supplier 1: Digi-Key; Stock 1: 9099863; Series: RC; RoHS Compliant: Yes; RoHS: Yes; Resistor Type: Thick Film; Resistance Tolerance: 1%; Radiation Hardening: No; Pricing 1: 1=0,10000, 10=0,03700, 100=0,01530, 1000=0,00685, 2500=0,00595, 5000=0,00491 (USD); Packaging: Tape and Reel; Package Quantity: 10000; Number of Terminations: 2; Min Operating Temperature: -55°C; Military Standard: Not; Max Power Dissipation: 50mW; Max Operating Temperature: 125°C; Length: 0.024inch; Lead Free: Lead Free; Height: 0.01inch; Features: Moisture Resistant; Depth: 0.3mm; Contact Plating: Tin,Matte; Construction: Rectangular; ComponentLink5URL: https://datasheet.ciiva.com/8896/pyu-rc0201-51-rohs-l-8-8896174.pdf?src-supplier=Avnet; ComponentLink5Description: https://datasheet.ciiva.com/8896/pyu-rc0201-51-rohs-l-8-8896174.pdf?src-supplier=Avnet; ComponentLink4URL: https://datasheet.ciiva.com/29721/pyu-r-int-thick-7-29721195.pdf?src-supplier=Digi-Key; ComponentLink4Description: https://datasheet.ciiva.com/29721/pyu-r-int-thick-7-29721195.pdf?src-supplier=Digi-Key; ComponentLink3URL: https://datasheet.ciiva.com/29721/pyu-r-int-thick-7-29721196.pdf?src-supplier=Digi-Key; ComponentLink3Description: https://datasheet.ciiva.com/29721/pyu-r-int-thick-7-29721196.pdf?src-supplier=Digi-Key; ComponentLink2URL: https://datasheet.ciiva.com/22698/1563626-22698505.pdf?src-supplier=Element14; ComponentLink2Description: https://datasheet.ciiva.com/22698/1563626-22698505.pdf?src-supplier=Element14; ComponentLink1URL: https://datasheet.ciiva.com/7672/1563626-7672204.pdf?src-supplier=Element14; ComponentLink1Description: https://datasheet.ciiva.com/7672/1563626-7672204.pdf?src-supplier=Element14; Component Kind: Standard; Case Code (Metric): 0603; Case Code (Imperial): 0201; Case/Package: 0201; Library Name: LimeMicroAltiumLib_schLib.SchLib
R62-R64 = Res_0402: Part Description: 4.7 kOhms ±1% 0.05W, 1/20W Chip Resistor 0201 (0603 Metric) Moisture Resistant Thick Film; Manufacturer Part Number 1: RC0201FR-074K7L; Manufacturer: Yageo; Voltage Rating: 25V; Temperature Coefficient: 200ppm/°C; Resistance: 4.7kR; Power Rating: 50mW; Width: 0.012inch; Weight: 6E-06oz; Value: 4.7k, 1%; Termination: SMD/SMT; Supplier Part Number 1: 311-4.70KMCT-ND; Supplier 1: Digi-Key; Stock 1: 6149397; Size Code: 0201; Series: RC; RoHS Compliant: Yes; RoHS: Yes; Resistor Type: Thick Film; Resistance Tolerance: 1%; Radiation Hardening: No; Pricing 1: 1=0,10000, 10=0,03700, 100=0,01530, 1000=0,00685, 2500=0,00595, 5000=0,00491 (USD); Packaging: Tape and Reel; Package Quantity: 1; Number of Terminations: 2; Min Operating Temperature: -55°C; Military Standard: Not; Max Power Dissipation: 50mW; Max Operating Temperature: 125°C; Length: 0.6mm; Lead Free: Lead Free; Height: 0.01inch; Features: Moisture Resistant; Depth: 0.3mm; Contact Plating: Tin,Matte; Construction: Rectangular; ComponentLink11URL: https://datasheet.ciiva.com/8892/pyu-rc0201-51-rohs-l-8-8892942.pdf?src-supplier=Avnet; ComponentLink11Description: https://datasheet.ciiva.com/8892/pyu-rc0201-51-rohs-l-8-8892942.pdf?src-supplier=Avnet; ComponentLink10URL: https://datasheet.ciiva.com/2493/pyu-r-int-thick-7-2493811.pdf?src-supplier=Digi-Key; ComponentLink10Description: https://datasheet.ciiva.com/2493/pyu-r-int-thick-7-2493811.pdf?src-supplier=Digi-Key; ComponentLink9URL: https://datasheet.ciiva.com/2493/pyu-r-int-thick-7-2493813.pdf?src-supplier=Digi-Key; ComponentLink9Description: https://datasheet.ciiva.com/2493/pyu-r-int-thick-7-2493813.pdf?src-supplier=Digi-Key; ComponentLink8URL: https://datasheet.ciiva.com/2493/pyu-r-int-thick-7-2493812.pdf?src-supplier=Digi-Key; ComponentLink8Description: https://datasheet.ciiva.com/2493/pyu-r-int-thick-7-2493812.pdf?src-supplier=Digi-Key; ComponentLink7URL: https://datasheet.ciiva.com/7672/1563626-7672233.pdf?src-supplier=Element14; ComponentLink7Description: https://datasheet.ciiva.com/7672/1563626-7672233.pdf?src-supplier=Element14; ComponentLink6URL: https://datasheet.ciiva.com/2493/pyu-r-int-thick-7-2493784.pdf?src-supplier=Digi-Key; ComponentLink6Description: https://datasheet.ciiva.com/2493/pyu-r-int-thick-7-2493784.pdf?src-supplier=Digi-Key; ComponentLink5URL: https://datasheet.ciiva.com/2493/pyu-r-int-thick-7-2493786.pdf?src-supplier=Digi-Key; ComponentLink5Description: https://datasheet.ciiva.com/2493/pyu-r-int-thick-7-2493786.pdf?src-supplier=Digi-Key; ComponentLink4URL: https://datasheet.ciiva.com/2493/pyu-r-int-thick-7-2493785.pdf?src-supplier=Digi-Key; ComponentLink4Description: https://datasheet.ciiva.com/2493/pyu-r-int-thick-7-2493785.pdf?src-supplier=Digi-Key; ComponentLink3URL: https://datasheet.ciiva.com/7672/1563626-7672202.pdf?src-supplier=Element14; ComponentLink3Description: https://datasheet.ciiva.com/7672/1563626-7672202.pdf?src-supplier=Element14; ComponentLink2URL: https://datasheet.ciiva.com/22661/1563626-22661319.pdf?src-supplier=Element14; ComponentLink2Description: https://datasheet.ciiva.com/22661/1563626-22661319.pdf?src-supplier=Element14; ComponentLink1URL: https://datasheet.ciiva.com/5924/1563626-5924912.pdf?src-supplier=Farnell; ComponentLink1Description: https://datasheet.ciiva.com/5924/1563626-5924912.pdf?src-supplier=Farnell; Component Kind: Standard; Case Code (Metric): 0603; Case Code (Imperial): 0201; Case/Package: 0201; Library Name: LimeFPGAboard_schLib.SchLib
T1 T5 T6 = 3600BL14M050_balun: Part Description: Signal Conditioning 3.6GHz 1:1 BALUN; Manufacturer Part Number 1: 3600BL14M050T; Manufacturer: Johanson; Return Loss: 9.5dB; Min Frequency: 3.3GHz; Max Frequency: 3.9GHz; Insertion Loss: 1.2dB; Impedance: 50R; Frequency: 3.6GHz; Termination: SMD/SMT; Supplier Part Number 1: 712-1048-1-ND; Supplier 1: Digi-Key; Stock 1: 41138; Series: 3600; RoHS: Yes; Resistor Type: Ceramic; Radiation Hardening: No; Pricing 1: 1=1,19000, 10=1,16900, 25=0,78280, 50=0,69680, 100=0,63840, 250=0,57280, 500=0,56086, 1000=0,40573 (USD); Packaging: Tape and Reel; Package Quantity: 1; Number of Pins: 6; Mount: Surface Mount; Min Operating Temperature: -40°C; Max Operating Temperature: 85°C; Length: 1.6mm; Depth: 0.8mm; ComponentLink3URL: https://datasheet.ciiva.com/24072/balun-3600bl14m050-242434-24072532.pdf?src-supplier=Mouser; ComponentLink3Description: https://datasheet.ciiva.com/24072/balun-3600bl14m050-242434-24072532.pdf?src-supplier=Mouser; ComponentLink2URL: https://datasheet.ciiva.com/22694/1318325-22694755.pdf?src-supplier=Element14; ComponentLink2Description: https://datasheet.ciiva.com/22694/1318325-22694755.pdf?src-supplier=Element14; ComponentLink1URL: https://datasheet.ciiva.com/2788/3600bl14m050-2788852.pdf?src-supplier=Digi-Key; ComponentLink1Description: https://datasheet.ciiva.com/2788/3600bl14m050-2788852.pdf?src-supplier=Digi-Key; Component Kind: Standard; Case Code (Metric): 1608; Case Code (Imperial): 0603; Case/Package: 0603; Library Name: LimeMicroAltiumLib_schLib_r04.SchLib
T2 T7 T8 = TC1-1-13M+: Part Description: RF balun; 1:1 ratio; 3 dB bandwidth: 0.3 MHz to 3.5 GHz; 3.8mm x 3.8mm; Manufacturer: Minicircuits; Supplier Part Number 1: TC1-1-13M-45+; Supplier 1: Minicircuits; Manufacturer Part Number 1: TC1-1-13M-45+; Component Kind: Standard; Library Name: LimeMicroAltiumLib_schLib.SchLib
T3 T4 = B0322J5050AHF_balun: Part Description: Signal Conditioning 300-2200MHz 50 Ohm IL=1.6dB; Manufacturer: Anaren; Insertion Loss: 1.6dB; Impedance: 50R; Termination: SMD/SMT; Supplier Part Number 1: 620-B0322J5050AHF; Supplier 1: Mouser; Stock 1: 19367; Series: Xinger®; RoHS Compliant: Yes; RoHS: Yes; Pricing 1: 1=1,65000, 10=1,02000, 50=1,02000, 100=0,88300, 1000=0,56100, 10000=0,52800 (USD); Packaging: Tape and Reel; Package Quantity: 1; Number of Pins: 6; Mount: Surface Mount; Min Operating Temperature: -55°C; Max Operating Temperature: 85°C; Manufacturer Part Number 1: B0322J5050AHF; Length: 2.04mm; Depth: 1.29mm; ComponentLink5URL: https://datasheet.ciiva.com/26819/getdatasheetpartid-573228-26819653.pdf?src-supplier=Verical; ComponentLink5Description: https://datasheet.ciiva.com/26819/getdatasheetpartid-573228-26819653.pdf?src-supplier=Verical; ComponentLink4URL: https://datasheet.ciiva.com/2788/b0322j5050ahf-2788998.pdf?src-supplier=Digi-Key; ComponentLink4Description: https://datasheet.ciiva.com/2788/b0322j5050ahf-2788998.pdf?src-supplier=Digi-Key; ComponentLink3URL: https://datasheet.ciiva.com/2788/b0322j5050ahf-2788997.pdf?src-supplier=Digi-Key; ComponentLink3Description: https://datasheet.ciiva.com/2788/b0322j5050ahf-2788997.pdf?src-supplier=Digi-Key; ComponentLink2URL: https://datasheet.ciiva.com/4548/b0322j5050ahf-datasheet-reva-18090-4548378.pdf?src-supplier=Mouser; ComponentLink2Description: https://datasheet.ciiva.com/4548/b0322j5050ahf-datasheet-reva-18090-4548378.pdf?src-supplier=Mouser; ComponentLink1URL: https://datasheet.ciiva.com/2788/b0322j5050ahf-datasheet-reva-2788996.pdf?src-supplier=Digi-Key; ComponentLink1Description: https://datasheet.ciiva.com/2788/b0322j5050ahf-datasheet-reva-2788996.pdf?src-supplier=Digi-Key; Component Kind: Standard; Case Code (Metric): 2012; Case Code (Imperial): 0805; Case/Package: 0805; Library Name: LimeMicroAltiumLib_schLib_r04.SchLib
X1 X2 X4 = RF_IF_connector: CONN UMC RCPT STR 50 OHM SMD; Part Description: Ultra Miniature Coaxial Connector Receptacle, Male Pin 50 Ohm Surface Mount Solder; Manufacturer Part Number 1: 0734120110; Manufacturer: Molex, LLC; Voltage Rating: 60V; Shield Termination: Solder; Impedance: 50 Ohm; Frequency - Max: 6GHz; Dielectric Material: Liquid Crystal Polymer (LCP); Connector Type: Jack, Male Pin; Connector Style: Ultra Miniature Coaxial; Center Contact Plating: Gold; Center Contact Material: Brass; Body Material: Phosphor Bronze; Body Finish: Nickel; Supplier Part Number 1: WM5587CT-ND; Supplier 1: Digi-Key; RoHS: RoHS Compliant; Packaging: Cut Tape (CT); Operating Temperature: -40°C ~ 90°C; Mounting Type: Surface Mount; Ingress Protection: -; Housing Color: Silver; Features: -; Fastening Type: Snap-On; Contact Termination: Solder; Component Kind: Standard; Category: Connectors, Interconnects; Cable Group: -; Library Name: LimeMicroAltiumLib_schLib.SchLib
